# Altium schematic sheet sheet.SchDoc
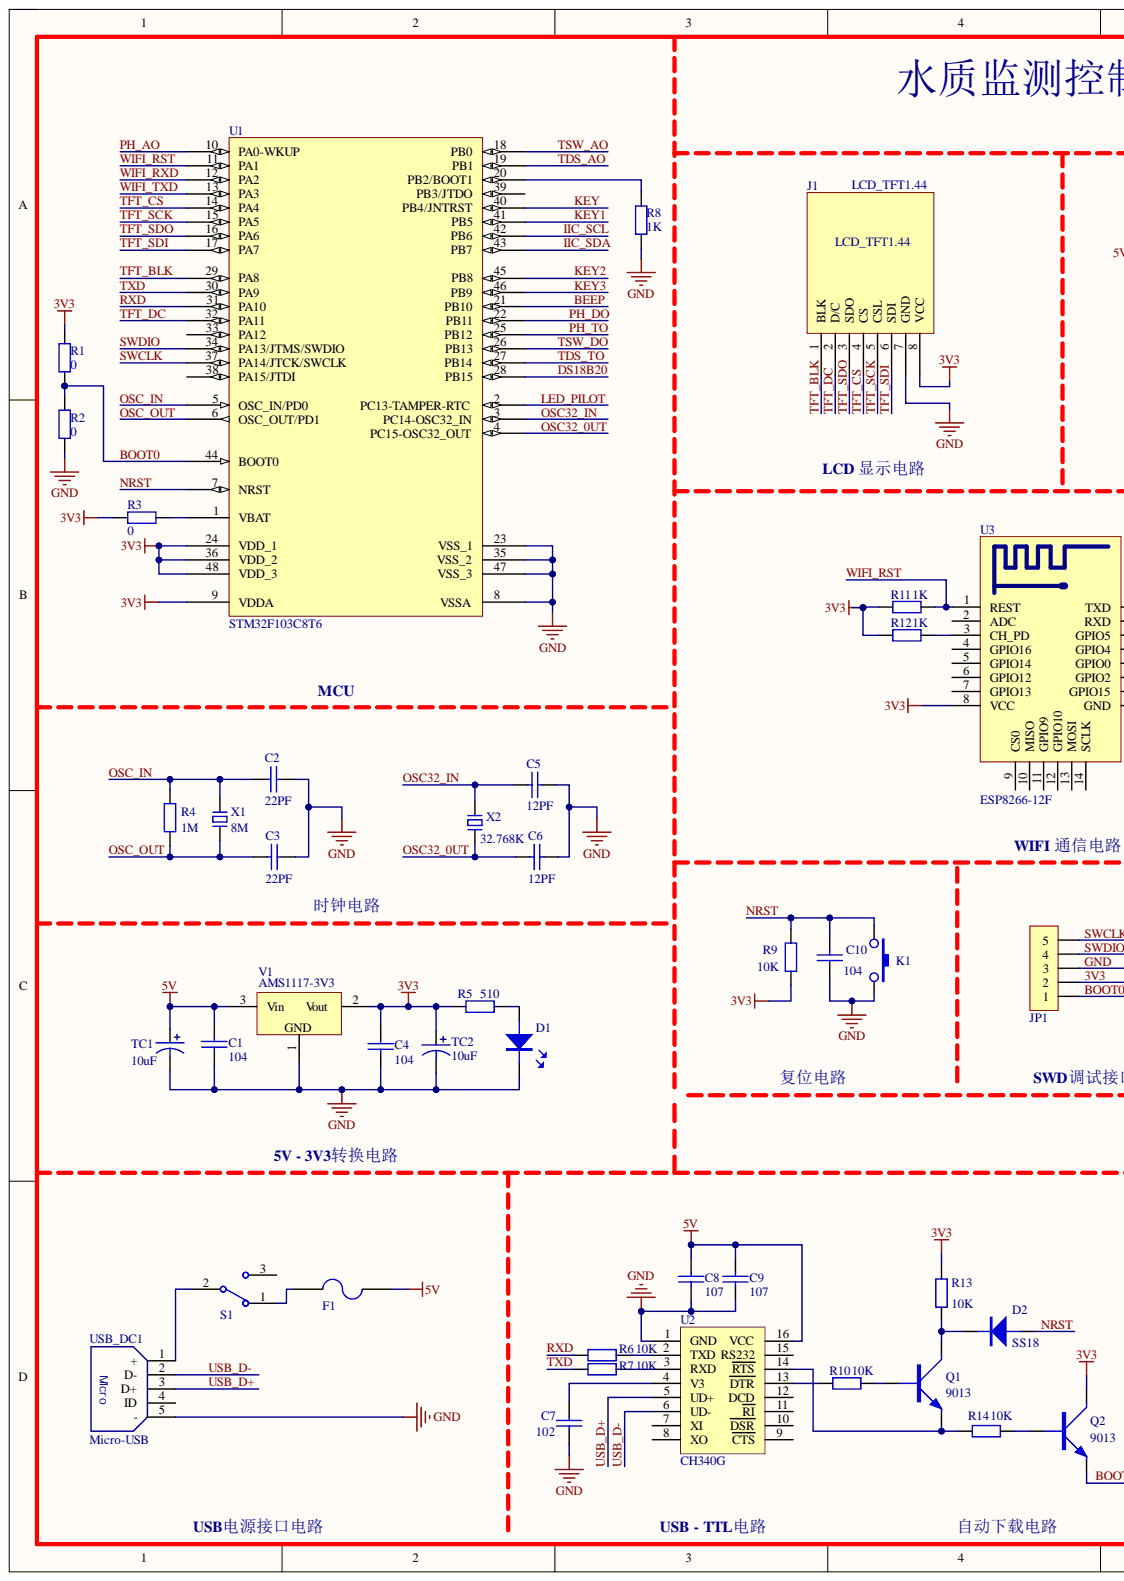
[diagram p0: sheet.SchDoc, left]
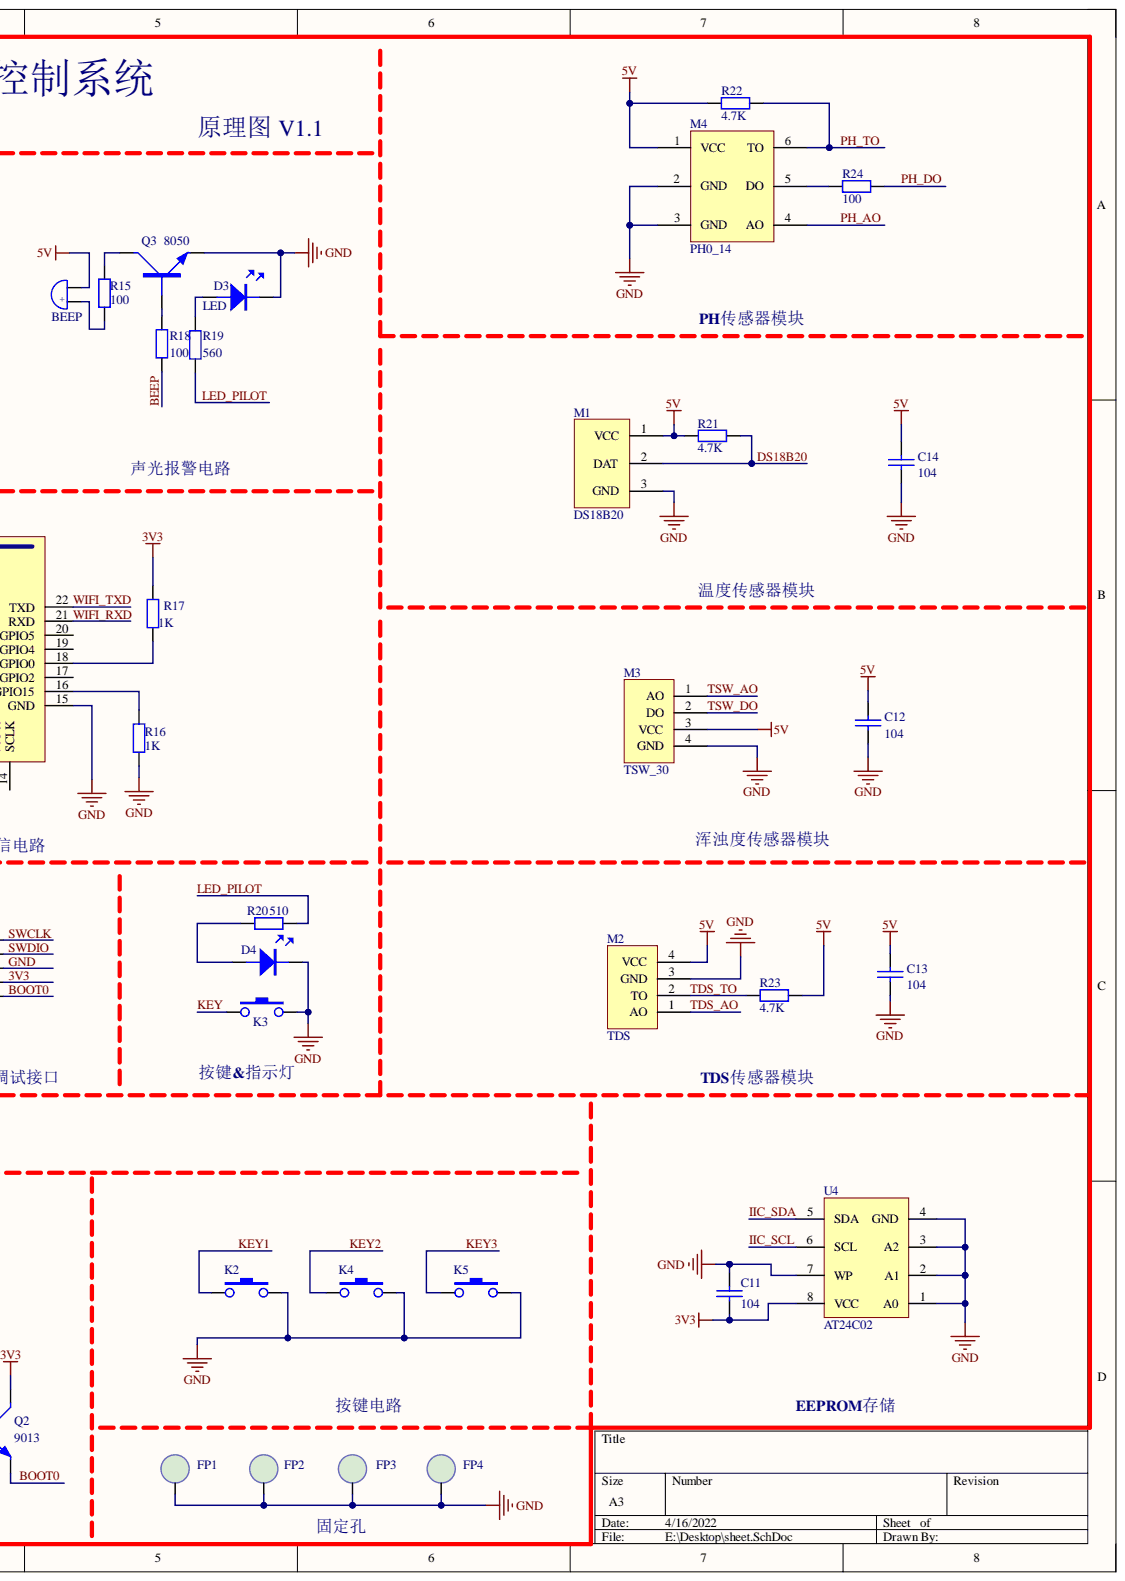
[diagram p1: sheet.SchDoc, right]

## Parts
B1  BEEP  [BEEP 7.6x12x7.5]
C1  value 104  [C0603_L]  (hidden comment: CAP)
C2  value 22PF  [C0603_L]  (hidden comment: CAP)
C3  value 22PF  [C0603_L]  (hidden comment: CAP)
C4  value 104  [C0603_L]  (hidden comment: CAP)
C5  value 12PF  [C0603_L]  (hidden comment: CAP)
C6  value 12PF  [C0603_L]  (hidden comment: CAP)
C7  value 102  [C0603_L]  (hidden comment: CAP)
C8  value 107  [C0603_L]  (hidden comment: CAP)
C9  value 107  [C0603_L]  (hidden comment: CAP)
C10  value 104  [C0603_L]  (hidden comment: CAP)
C11  value 104  [C0603_L]  (hidden comment: CAP)
C12  value 104  [C0603_L]  (hidden comment: CAP)
C13  value 104  [C0603_L]  (hidden comment: CAP)
C14  value 104  [C0603_L]  (hidden comment: CAP)
D1  LED  [LED0805_R]  (value not drawn)
D2  SS18  [SMA]
D3  LED  [0603_LED_S1]
D4  LED  [LED0805_R]  (value not drawn)
F1  FUSE  [FU 0805]  (value not drawn)
FP1  Fixed_Pad  [固定孔]  (value not drawn)
FP2  Fixed_Pad  [固定孔]  (value not drawn)
FP3  Fixed_Pad  [固定孔]  (value not drawn)
FP4  Fixed_Pad  [固定孔]  (value not drawn)
J1  LCD_TFT1.44  [HDR2.54-M-LI-8P]
JP1  HDR-1X5  [HDR2.54-LI-5P]  (value not drawn)
K1  KEY  [SW SMD-3*6*2.5]  (value not drawn)
K2  KEY  [SW SMD-3*6*2.5]
K3  KEY  [SW SMD-6*6*5]
K4  KEY  [SW SMD-6*6*5]
K5  KEY  [SW SMD-6*6*5]
M1  DS18B20  [KF301-5.0-3P]
M2  TDS  [KF301-5.0-4P]
M3  TSW_30  [KF301-5.0-4P]
M4  PH0_14  [KF301-5.0-6P]
Q1  9013  [SOT23-3L]
Q2  9013  [SOT23-3L]
Q3  8050  [SOT23-3L]
R1  value 0  [R0603_L]  (hidden comment: Res2)
R2  value 0  [R0603_L]  (hidden comment: Res2)
R3  value 0  [R0603_L]  (hidden comment: Res2)
R4  value 1M  [R0603_L]  (hidden comment: Res2)
R5  value 510  [R0603_L]  (hidden comment: Res2)
R6  value 10K  [R0603_L]  (hidden comment: Res2)
R7  value 10K  [R0603_L]  (hidden comment: Res2)
R8  value 1K  [R0603_L]  (hidden comment: Res2)
R9  value 10K  [R0603_L]  (hidden comment: Res2)
R10  value 10K  [R0603_L]  (hidden comment: Res2)
R11  value 1K  [R0603_L]  (hidden comment: Res2)
R12  value 1K  [R0603_L]  (hidden comment: Res2)
R13  value 10K  [R0603_L]  (hidden comment: Res2)
R14  value 10K  [R0603_L]  (hidden comment: Res2)
R15  value 100  [R0603_L]  (hidden comment: Res2)
R16  value 1K  [R0603_L]  (hidden comment: Res2)
R17  value 1K  [R0603_L]  (hidden comment: Res2)
R18  value 100  [R0603_L]  (hidden comment: Res2)
R19  value 560  [R0603_L]  (hidden comment: Res2)
R20  value 510  [R0603_L]  (hidden comment: Res2)
R21  value 4.7K  [R0603_L]  (hidden comment: Res2)
R22  value 4.7K  [R0603_L]  (hidden comment: Res2)
R23  value 4.7K  [R0603_L]  (hidden comment: Res2)
R24  value 100  [R0603_L]  (hidden comment: Res2)
S1  SS-12F23  [KFT DIP-8X8]  (value not drawn)
TC1  10uF  [C_3216]
TC2  10uF  [C_3216]
U1  STM32F103C8T6  [LQFP48-7*7]
U2  CH340G  [SOP16]
U3  ESP8266-12F  [ESP-12E]
U4  AT24C02  [SOP8]
USB_DC1  Micro-USB  [USB2.0_MICRO-B_5]
V1  AMS1117-3V3  [SOT-223]
X1  value 8M  [HC-49SMD]  (hidden comment: XTAL-2P)
X2  value 32.768K  [OSC-3215-2P]  (hidden comment: XTAL-2P)

## Nets
5V: B1.2 C1.2 C8.2 C9.2 C12.2 C13.2 C14.2 F1.1 M1.1 M2.4(VCC) M3.3(VCC) M4.1(VCC) R21.2 R22.2 R23.2 TC1.1 U2.16(VCC) V1.3
3V3: C4.2 C11.1 J1.8(VCC) JP1.2 Q2.C R1.2 R3.1 R5.1 R9.2 R11.2 R12.2 R13.2 R17.2 TC2.1 U1.9(VDDA) U1.24(VDD_1) U1.36(VDD_2) U1.48(VDD_3) U3.8(VCC) U4.8(VCC) V1.2
BEEP: R18.2 U1.21(PB10)
BOOT0: JP1.1 Q2.E R1.1 R2.2 U1.44(BOOT0)
DS18B20: M1.2 R21.1 U1.28(PB15)
GND: C1.1 C2.2 C3.1 C4.1 C5.1 C6.1 C7.2 C8.1 C9.1 C10.1 C11.2 C12.1 C13.1 C14.1 D1.K D3.K D4.K FP1.1 FP2.1 FP3.1 FP4.1 J1.7(GND) JP1.3 K1.3 K2.3 K3.3 K4.3 K5.3 M1.3 M2.3(GND) M3.4(GND) M4.2(GND) M4.3(GND) Q3.E R2.1 R8.2 R16.1 TC1.2 TC2.2 U1.8(VSSA) U1.23(VSS_1) U1.35(VSS_2) U1.47(VSS_3) U2.1(GND) U3.15(GND) U4.1(A0) U4.2(A1) U4.3(A2) U4.4(GND) U4.7(WP) USB_DC1.5(-) V1.1
IIC_SCL: U1.42(PB6) U4.6(SCL)
IIC_SDA: U1.43(PB7) U4.5(SDA)
KEY: K3.1 U1.40(PB4/JNTRST)
KEY1: K2.1 U1.41(PB5)
KEY2: K4.1 U1.45(PB8)
KEY3: K5.1 U1.46(PB9)
LED_PILOT: R19.1 R20.2 U1.2(PC13-TAMPER-RTC)
NRST: C10.2 D2.A K1.1 R9.1 U1.7(NRST)
OSC32_0UT: C6.2 U1.4(PC15-OSC32_OUT) X2.2
OSC32_IN: C5.2 U1.3(PC14-OSC32_IN) X2.1
OSC_IN: C2.1 R4.1 U1.5(OSC_IN/PD0) X1.1
OSC_OUT: C3.2 R4.2 U1.6(OSC_OUT/PD1) X1.2
PH_AO: M4.4(AO) U1.10(PA0-WKUP)
PH_DO: R24.2 U1.22(PB11)
PH_TO: M4.6(TO) R22.1 U1.25(PB12)
RXD: R6.2 U1.31(PA10)
SWCLK: JP1.5 U1.37(PA14/JTCK/SWCLK)
SWDIO: JP1.4 U1.34(PA13/JTMS/SWDIO)
TDS_AO: M2.1(AO) U1.19(PB1)
TDS_TO: M2.2(TO) R23.1 U1.27(PB14)
TFT_BLK: J1.1(BLK) U1.29(PA8)
TFT_CS: J1.4(CS) U1.14(PA4)
TFT_DC: J1.2(D/C) U1.32(PA11)
TFT_SCK: J1.5(CSL) U1.15(PA5)
TFT_SDI: J1.6(SDI) U1.17(PA7)
TFT_SDO: J1.3(SDO) U1.16(PA6)
TSW_AO: M3.1(AO) U1.18(PB0)
TSW_DO: M3.2(DO) U1.26(PB13)
TXD: R7.1 U1.30(PA9)
USB_D+: U2.5(UD+) USB_DC1.3(D+)
USB_D-: U2.6(UD-) USB_DC1.2(D-)
WIFI_RST: R11.1 U1.11(PA1) U3.1(REST)
WIFI_RXD: U1.12(PA2) U3.21(RXD)
WIFI_TXD: U1.13(PA3) U3.22(TXD)
NetB1_1: B1.1 R15.1
NetC7_1: C7.1 U2.4(V3)
NetD1_A: D1.A R5.2
NetD2_K: D2.K Q1.C R13.1
NetD3_A: D3.A R19.2
NetD4_A: D4.A R20.1
NetF1_2: F1.2 S1.1
NetM4_5: M4.5(DO) R24.1
NetQ1_B: Q1.B R10.2
NetQ1_E: Q1.E R14.1 U2.14(RTS)
NetQ2_B: Q2.B R14.2
NetQ3_B: Q3.B R18.1
NetQ3_C: Q3.C R15.2
NetR3_2: R3.2 U1.1(VBAT)
NetR6_1: R6.1 U2.2(TXD)
NetR7_2: R7.2 U2.3(RXD)
NetR8_1: R8.1 U1.20(PB2/BOOT1)
NetR10_1: R10.1 U2.13(DTR)
NetR12_1: R12.1 U3.3(CH_PD)
NetR16_2: R16.2 U3.16(GPIO15)
NetR17_1: R17.1 U3.18(GPIO0)
NetS1_2: S1.2 USB_DC1.1(+)

Not connected: S1 3; U1 33(PA12) 38(PA15/JTDI) 39(PB3/JTDO); U2 7(XI) 8(XO) 9(CTS) 10(DSR) 11(RI) 12(DCD) 15(RS232); U3 2(ADC) 4(GPIO16) 5(GPIO14) 6(GPIO12) 7(GPIO13) 9(CS0) 10(MISO) 11(GPIO9) 12(GPIO10) 13(MOSI) 14(SCLK) 17(GPIO2) 19(GPIO4) 20(GPIO5); USB_DC1 4(ID)

## Library data of the parts
B1 = BEEP: 蜂鸣器; Component Kind: Standard; Library Name: 蜂鸣器.IntLib
C1-C14 = CAP: 无极性电容; Component Kind: Standard; Library Name: 电容.IntLib
D2 = DIODE: 普通二极管; Component Kind: Standard; Library Name: 二极管.IntLib
JP1 = Header 5: 5P接插件; 版权归属: 源创客; BOM名称: 5P接插件; Component Kind: Standard; Library Name: 4.6   - 接插件-脚距3.5.SchLib; 学习资源: www.ooocke.com
Q1-Q3 = NPN: NPN三极管; Component Kind: Standard; Library Name: 三极管.IntLib
R1-R24 = Res2: Resistor; Component Kind: Standard; LatestRevisionDate: 17-Jul-2002; LatestRevisionNote: Re-released for DXP Platform.; Library Name: Miscellaneous Devices.IntLib; PackageDescription: Axial Device, Thru-Hole; 2 Leads; 0.4 in Pin Spacing; PackageReference: AXIAL-0.4; Published: 8-Jun-2000; Signal Integrity: Res; Simulation: RESISTOR; Publisher: Altium Limited
TC1 TC2 = TCAP: 钽电容; Component Kind: Standard; Library Name: 电容.IntLib
U3 = ESP8266-12E: 串口 WIFI模块，ESP-12E; BOM名称: WIFI模块; 版权归属: 源创客; Component Kind: Standard; Library Name: 13.1 - 模块.SchLib; 学习资源: www.ooocke.com
V1 = AMS1117: 三端稳压芯片; BOM名称: 稳压芯片; 版权归属: 源创客; Component Kind: Standard; Library Name: 1.3   - 电源类芯片.SchLib; 学习资源: www.ooocke.com
X1 X2 = XTAL-2P: 2脚无源脚晶振; Component Kind: Standard; Library Name: 晶振.IntLib
D1 D3 D4 = LED: Component Kind: Standard; Library Name: LED.IntLib
F1 = FUSE: Component Kind: Standard; Library Name: 保险丝.IntLib
FP1-FP4 = Fixed_Pad: Component Kind: Standard; Library Name: SamacSys.SchLib
J1 = LCD_TFT1.44: Component Kind: Standard; Library Name: 元件库.SchLib
K1-K5 = KEY: Component Kind: Standard; Library Name: 轻触开关.IntLib
M1 = DS18B20: Component Kind: Standard; Library Name: 元件库.SchLib
M2 = TDS: Component Kind: Standard; Library Name: 元件库.SchLib
M3 = TSW_30: Component Kind: Standard; Library Name: 元件库.SchLib
M4 = PH0_14: Component Kind: Standard; Library Name: 元件库.SchLib
S1 = SS-12F23: Component Kind: Standard; Library Name: 电源开关.IntLib
U1 = STM32F103C8T6: Component Kind: Standard; Library Name: STM32系列.IntLib
U2 = CH340G: Component Kind: Standard; Library Name: 通讯系列IC.IntLib
U4 = AT24C02: Component Kind: Standard; Library Name: 未分类IC.IntLib
USB_DC1 = Micro-USB: Component Kind: Standard; Library Name: USB.IntLib
